# Altium schematic sheet Sheet1.SchDoc(Sheet1)
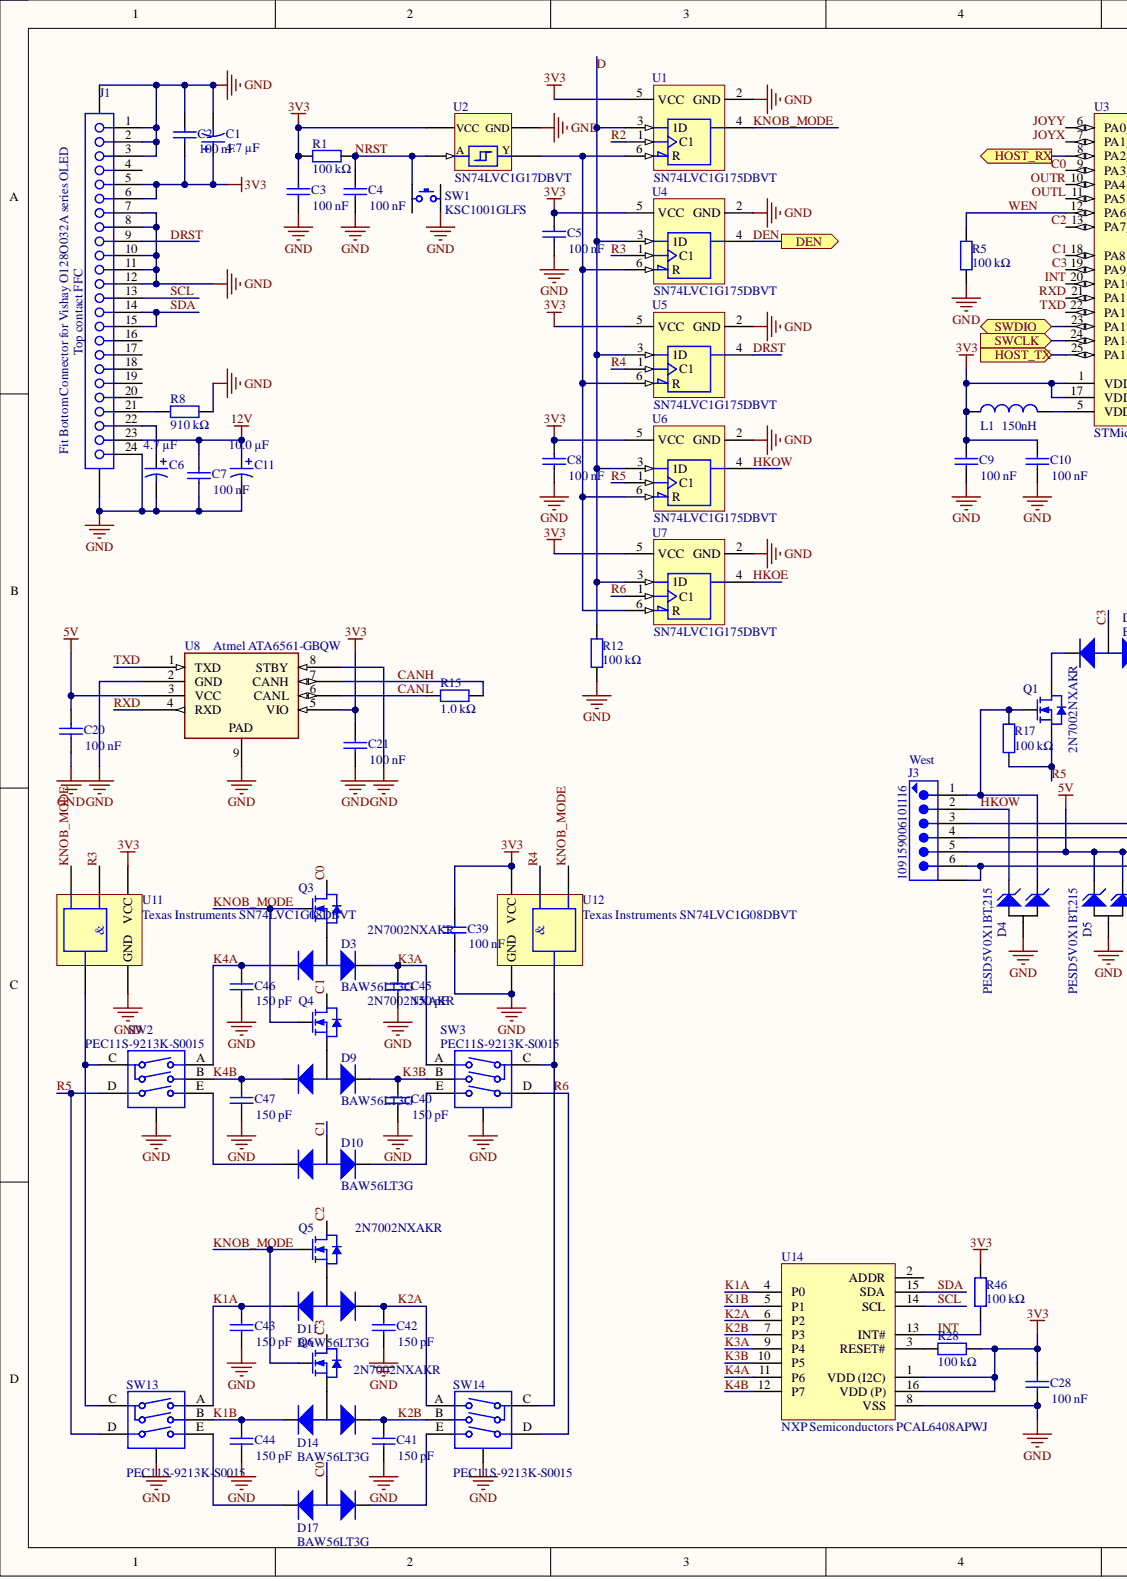
[diagram p0: Sheet1.SchDoc, left]
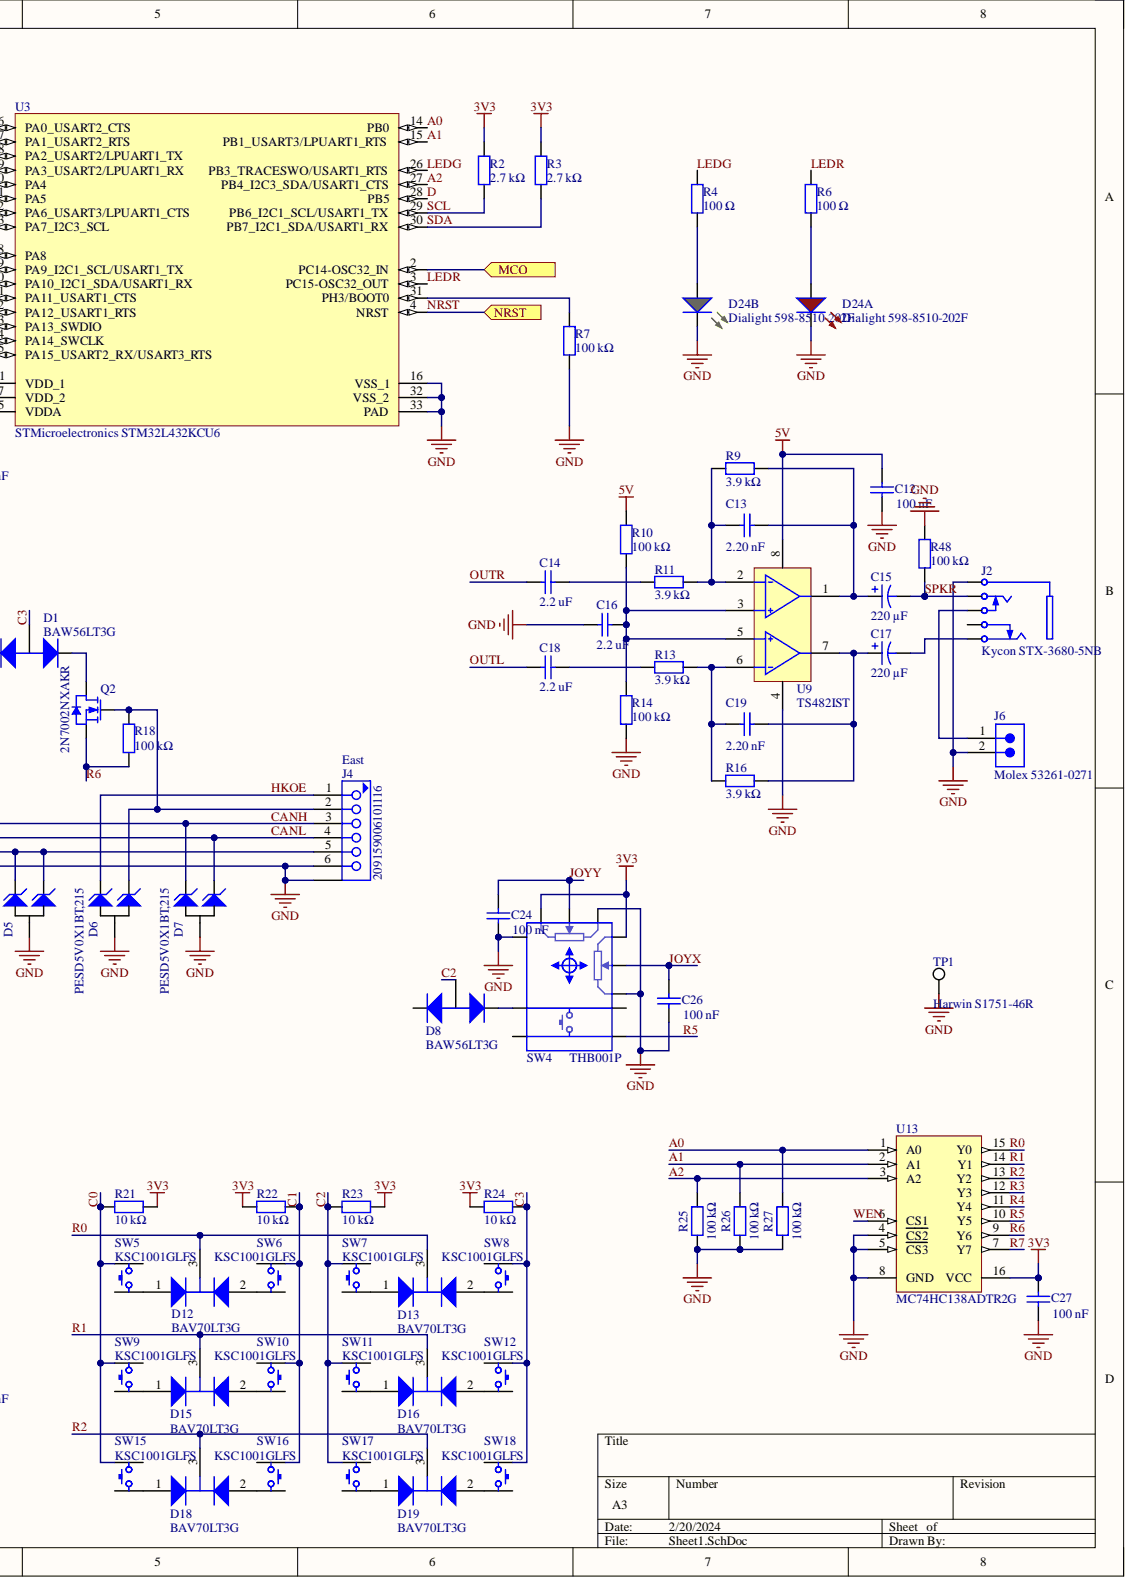
[diagram p1: Sheet1.SchDoc, right]

## Parts
C1  Capacitance: 4.7 µF  [PCB-4j0sn5jniln07mi7kvmx-1]  (hidden comment: AVX TAJB475K025RNJ)
C2  Yageo CC0603ZRY5V8BB104  (value not drawn)
C3  Yageo CC0603ZRY5V8BB104  (value not drawn)
C4  Capacitance: 100 nF  (hidden comment: Yageo CC0603ZRY5V8BB104)
C5  Capacitance: 100 nF  (hidden comment: Yageo CC0603ZRY5V8BB104)
C6  Capacitance: 4.7 µF  [PCB-4j0sn5jniln07mi7kvmx-1]  (hidden comment: AVX TAJB475K025RNJ)
C7  Yageo CC0603ZRY5V8BB104  (value not drawn)
C8  Capacitance: 100 nF  (hidden comment: Yageo CC0603ZRY5V8BB104)
C9  Yageo CC0603ZRY5V8BB104  (value not drawn)
C10  Capacitance: 100 nF  (hidden comment: Yageo CC0603ZRY5V8BB104)
C11  Capacitance: 10.0 µF  [PCB-4j0sn5jniln07mi7kvmx-1]  (hidden comment: AVX TAJB106K025RNJ)
C12  Capacitance: 100 nF  (hidden comment: Yageo CC0603ZRY5V8BB104)
C13  Capacitance: 2.20 nF  (hidden comment: Yageo CC0603KRX7R7BB222)
C14  Capacitance: 2.2 uF  (hidden comment: Yageo CC0603KRX5R8BB225)
C15  Capacitance: 220 µF  (hidden comment: Würth Elektronik 865080345012)
C16  Capacitance: 2.2 uF  (hidden comment: Yageo CC0603KRX5R8BB225)
C17  Capacitance: 220 µF  (hidden comment: Würth Elektronik 865080345012)
C18  Capacitance: 2.2 uF  (hidden comment: Yageo CC0603KRX5R8BB225)
C19  Capacitance: 2.20 nF  (hidden comment: Yageo CC0603KRX7R7BB222)
C20  Capacitance: 100 nF  (hidden comment: Yageo CC0603ZRY5V8BB104)
C21  Capacitance: 100 nF  (hidden comment: Yageo CC0603ZRY5V8BB104)
C24  Yageo CC0603ZRY5V8BB104  (value not drawn)
C26  Capacitance: 100 nF  (hidden comment: Yageo CC0603ZRY5V8BB104)
C27  Capacitance: 100 nF  (hidden comment: Yageo CC0603ZRY5V8BB104)
C28  Capacitance: 100 nF  (hidden comment: Yageo CC0603ZRY5V8BB104)
C39  Yageo CC0603ZRY5V8BB104  (value not drawn)
C40  Yageo CC0603JPNPO9BN151  (value not drawn)
C41  Yageo CC0603JPNPO9BN151  (value not drawn)
C42  Capacitance: 150 pF  (hidden comment: Yageo CC0603JPNPO9BN151)
C43  Yageo CC0603JPNPO9BN151  (value not drawn)
C44  Capacitance: 150 pF  (hidden comment: Yageo CC0603JPNPO9BN151)
C45  Capacitance: 150 pF  (hidden comment: Yageo CC0603JPNPO9BN151)
C46  Yageo CC0603JPNPO9BN151  (value not drawn)
C47  Capacitance: 150 pF  (hidden comment: Yageo CC0603JPNPO9BN151)
D1  BAW56LT3G
D3  BAW56LT3G
D4  Manufacturer Part Number: PESD5V0X1BT,215  (hidden comment: Nexperia PESD5V0X1BT,215)
D5  Manufacturer Part Number: PESD5V0X1BT,215  (hidden comment: Nexperia PESD5V0X1BT,215)
D6  Manufacturer Part Number: PESD5V0X1BT,215  (hidden comment: Nexperia PESD5V0X1BT,215)
D7  Manufacturer Part Number: PESD5V0X1BT,215  (hidden comment: Nexperia PESD5V0X1BT,215)
D8  BAW56LT3G
D9  BAW56LT3G
D10  BAW56LT3G
D11  BAW56LT3G
D12  BAV70LT3G
D13  BAV70LT3G
D14  BAW56LT3G
D15  BAV70LT3G
D16  BAV70LT3G
D17  BAW56LT3G
D18  BAV70LT3G
D19  BAV70LT3G
D24  Dialight 598-8510-202F  Manufacturer Part Number: 598-8510-202F  (parts A,B)
J1  52435-2433  (value not drawn)
J2  Kycon STX-3680-5NB  Manufacturer Part Number: STX-3680-5NB  [PCB-zl7nupvjblerxkmcmnl5-1]
J3  Manufacturer Part Number: 109159006101116  (hidden comment: AVX 109159006101116)
J4  209159006101116
J6  Molex 53261-0271  Manufacturer Part Number: 53261-0271
L1  value 150nH  (hidden comment: Eaton MCQ1V1608-R150-R)
Q1  Manufacturer Part Number: 2N7002NXAKR  (hidden comment: Nexperia 2N7002NXAKR)
Q2  Manufacturer Part Number: 2N7002NXAKR  (hidden comment: Nexperia 2N7002NXAKR)
Q3  Nexperia 2N7002NXAKR  (value not drawn)
Q4  Nexperia 2N7002NXAKR  (value not drawn)
Q5  Manufacturer Part Number: 2N7002NXAKR  (hidden comment: Nexperia 2N7002NXAKR)
Q6  Manufacturer Part Number: 2N7002NXAKR  (hidden comment: Nexperia 2N7002NXAKR)
R1  Resistance: 100 kΩ  (hidden comment: Yageo RC0603FR-07100KL)
R2  Resistance: 2.7 kΩ  (hidden comment: Yageo RC0603FR-102K7L)
R3  Resistance: 2.7 kΩ  (hidden comment: Yageo RC0603FR-102K7L)
R4  Resistance: 100 Ω  (hidden comment: Yageo RC0603FR-07100RL)
R5  Resistance: 100 kΩ  (hidden comment: Yageo RC0603FR-07100KL)
R6  Resistance: 100 Ω  (hidden comment: Yageo RC0603FR-07100RL)
R7  Resistance: 100 kΩ  (hidden comment: Yageo RC0603FR-07100KL)
R8  Resistance: 910 kΩ  (hidden comment: Yageo RC0603FR-07910KL)
R9  Resistance: 3.9 kΩ  (hidden comment: Yageo RC0603FR-073K9L)
R10  Resistance: 100 kΩ  (hidden comment: Yageo RC0603FR-07100KL)
R11  Resistance: 3.9 kΩ  (hidden comment: Yageo RC0603FR-073K9L)
R12  Resistance: 100 kΩ  (hidden comment: Yageo RC0603FR-07100KL)
R13  Resistance: 3.9 kΩ  (hidden comment: Yageo RC0603FR-073K9L)
R14  Resistance: 100 kΩ  (hidden comment: Yageo RC0603FR-07100KL)
R15  Resistance: 1.0 kΩ  (hidden comment: Yageo RC0603FR-071KL)
R16  Resistance: 3.9 kΩ  (hidden comment: Yageo RC0603FR-073K9L)
R17  Yageo RC0603FR-07100KL  (value not drawn)
R18  Resistance: 100 kΩ  (hidden comment: Yageo RC0603FR-07100KL)
R21  Resistance: 10 kΩ  (hidden comment: Yageo RC0603FR-0710KL)
R22  Resistance: 10 kΩ  (hidden comment: Yageo RC0603FR-0710KL)
R23  Resistance: 10 kΩ  (hidden comment: Yageo RC0603FR-0710KL)
R24  Resistance: 10 kΩ  (hidden comment: Yageo RC0603FR-0710KL)
R25  Resistance: 100 kΩ  (hidden comment: Yageo RC0603FR-07100KL)
R26  Resistance: 100 kΩ  (hidden comment: Yageo RC0603FR-07100KL)
R27  Resistance: 100 kΩ  (hidden comment: Yageo RC0603FR-07100KL)
R28  Resistance: 100 kΩ  (hidden comment: Yageo RC0603FR-07100KL)
R46  Resistance: 100 kΩ  (hidden comment: Yageo RC0603FR-07100KL)
R48  Resistance: 100 kΩ  (hidden comment: Yageo RC0603FR-07100KL)
SW1  Manufacturer Part Number: KSC1001GLFS  (hidden comment: C&K Components KSC1001GLFS)
SW2  Manufacturer Part Number: PEC11S-9213K-S0015  (hidden comment: Bourns PEC11S-9213K-S0015)
SW3  Manufacturer Part Number: PEC11S-9213K-S0015  (hidden comment: Bourns PEC11S-9213K-S0015)
SW4  Manufacturer Part Number: THB001P  (hidden comment: C&K Components THB001P)
SW5  Manufacturer Part Number: KSC1001GLFS  (hidden comment: C&K Components KSC1001GLFS)
SW6  Manufacturer Part Number: KSC1001GLFS  (hidden comment: C&K Components KSC1001GLFS)
SW7  Manufacturer Part Number: KSC1001GLFS  (hidden comment: C&K Components KSC1001GLFS)
SW8  Manufacturer Part Number: KSC1001GLFS  (hidden comment: C&K Components KSC1001GLFS)
SW9  Manufacturer Part Number: KSC1001GLFS  (hidden comment: C&K Components KSC1001GLFS)
SW10  Manufacturer Part Number: KSC1001GLFS  (hidden comment: C&K Components KSC1001GLFS)
SW11  Manufacturer Part Number: KSC1001GLFS  (hidden comment: C&K Components KSC1001GLFS)
SW12  Manufacturer Part Number: KSC1001GLFS  (hidden comment: C&K Components KSC1001GLFS)
SW13  Manufacturer Part Number: PEC11S-9213K-S0015  (hidden comment: Bourns PEC11S-9213K-S0015)
SW14  Manufacturer Part Number: PEC11S-9213K-S0015  (hidden comment: Bourns PEC11S-9213K-S0015)
SW15  Manufacturer Part Number: KSC1001GLFS  (hidden comment: C&K Components KSC1001GLFS)
SW16  Manufacturer Part Number: KSC1001GLFS  (hidden comment: C&K Components KSC1001GLFS)
SW17  Manufacturer Part Number: KSC1001GLFS  (hidden comment: C&K Components KSC1001GLFS)
SW18  Manufacturer Part Number: KSC1001GLFS  (hidden comment: C&K Components KSC1001GLFS)
TP1  Harwin S1751-46R  Manufacturer Part Number: S1751-46R
U1  Manufacturer Part Number: SN74LVC1G175DBVT  (hidden comment: Texas Instruments SN74LVC1G175DBV)
U2  SN74LVC1G17DBVT
U3  STMicroelectronics STM32L432KCU6
U4  Manufacturer Part Number: SN74LVC1G175DBVT  (hidden comment: Texas Instruments SN74LVC1G175DBV)
U5  Manufacturer Part Number: SN74LVC1G175DBVT  (hidden comment: Texas Instruments SN74LVC1G175DBV)
U6  Manufacturer Part Number: SN74LVC1G175DBVT  (hidden comment: Texas Instruments SN74LVC1G175DBV)
U7  Manufacturer Part Number: SN74LVC1G175DBVT  (hidden comment: Texas Instruments SN74LVC1G175DBV)
U8  Atmel ATA6561-GBQW  Manufacturer Part Number: ATA6561-GBQW
U9  Manufacturer Part Number: TS482IST  (hidden comment: STMicroelectronics TS482IST)
U11  Texas Instruments SN74LVC1G08DBVT
U12  Texas Instruments SN74LVC1G08DBVT
U13  MC74HC138ADTR2G
U14  NXP Semiconductors PCAL6408APWJ  Manufacturer Part Number: PCAL6408APWJ

## Ports
DEN, HOST_RX, HOST_TX, MCO, NRST, SWCLK, SWDIO

## Nets
3V3: C1.1 C2.2 C3.1 C5.1 C8.1 C9.1 C10.1 C21.1 C27.1 C28.1 C39.1 J1.5 J1.6 L1.1 R1.1 R2.2 R3.2 R21.1 R22.2 R23.1 R24.2 R28.1 R46.1 SW4.X3(nF) SW4.Y3 U1.5(VCC) U2.5(VCC) U3.1(VDD_1) U3.17(VDD_2) U4.5(VCC) U5.5(VCC) U6.5(VCC) U7.5(VCC) U8.5(VIO) U11.5(VCC) U12.5(VCC) U13.16(VCC) U14.1(VDD_(I2C)) U14.16(VDD_(P))
5V: C12.1 C20.1 D5.1 D5.2 J3.5 J4.5 R10.2 U8.3(VCC) U9.8
12V: C7.1 C11.1 J1.23
GND: C1.2 C2.1 C3.2 C4.2 C5.2 C6.2 C7.2 C8.2 C9.2 C10.2 C11.2 C12.2 C16.2 C20.2 C21.2 C24.2 C26.2 C27.2 C28.2 C39.2 C40.2 C41.2 C42.2 C43.2 C44.2 C45.2 C46.2 C47.2 D4.3 D5.3 D6.3 D7.3 D24.2 D24.4 J1.1 J1.2 J1.3 J1.7 J1.8 J1.10 J1.11 J1.12 J1.24 J2.5 J3.0 J3.6 J4.0 J4.6 J6.2 R5.1 R7.1 R8.2 R12.1 R14.1 R25.1 R26.1 R27.1 R48.2 SW1.3 SW2.0 SW3.0 SW4.0 SW4.X1 SW4.Y1 SW13.0 SW14.0 TP1.1 U1.2(GND) U2.3(GND) U3.16(VSS_1) U3.32(VSS_2) U3.33(PAD) U4.2(GND) U5.2(GND) U6.2(GND) U7.2(GND) U8.2(GND) U8.8(STBY) U8.9 U9.4 U11.3(GND) U12.3(GND) U13.4(CS2) U13.5(CS3) U13.8(GND) U14.8(VSS)
A0: R27.2 U3.14(PB0) U13.1(A0)
A1: R26.2 U3.15(PB1_USART3/LPUART1_RTS) U13.2(A1)
A2: R25.2 U3.27(PB4_I2C3_SDA/USART1_CTS) U13.3(A2)
C0: D17.3 Q3.3 R21.2 SW5.4 SW9.4 SW15.4 U3.9(PA3_USART2/LPUART1_RX)
C1: D10.3 Q4.3 R22.1 SW6.2 SW10.2 SW16.2 U3.18(PA8)
C2: D8.3 Q5.3 R23.2 SW7.4 SW11.4 SW17.4 U3.13(PA7_I2C3_SCL)
C3: D1.3 Q6.3 R24.1 SW8.2 SW12.2 SW18.2 U3.19(PA9_I2C1_SCL/USART1_TX)
CANH: D7.1 J3.3 J4.3 R15.1 U8.7(CANH)
CANL: D7.2 J3.4 J4.4 R15.2 U8.6(CANL)
D: R12.2 U1.3(1D) U3.28(PB5) U4.3(1D) U5.3(1D) U6.3(1D) U7.3(1D)
DEN: U4.4 ; port DEN
DRST: J1.9 U5.4
HKOE: D6.1 J4.1 U7.4
HKOW: D4.1 J3.2 U6.4
HOST_RX: U3.8(PA2_USART2/LPUART1_TX) ; port HOST_RX
HOST_TX: U3.25(PA15_USART2_RX/USART3_RTS) ; port HOST_TX
INT: R46.2 U3.20(PA10_I2C1_SDA/USART1_RX) U14.13(INT#)
JOYX: C26.1 SW4.Y2 U3.7(PA1_USART2_RTS)
JOYY: C24.1 SW4.X2 U3.6(PA0_USART2_CTS)
K1A: C43.1 D11.1 SW13.A U14.4(P0)
K1B: C44.1 D14.1 SW13.B U14.5(P1)
K2A: C42.1 D11.2 SW14.A U14.6(P2)
K2B: C41.1 D14.2 SW14.B U14.7(P3)
K3A: C45.1 D3.1 SW3.A U14.9(P4)
K3B: C40.1 D9.1 SW3.B U14.10(P5)
K4A: C46.1 D3.2 SW2.A U14.11(P6)
K4B: C47.1 D9.2 SW2.B U14.12(P7)
KNOB_MODE: Q3.1 Q4.1 Q5.1 Q6.1 U1.4 U11.2 U12.2
LEDG: R4.2 U3.26(PB3_TRACESWO/USART1_RTS)
LEDR: R6.2 U3.3(PC15-OSC32_OUT)
MCO: U3.2(PC14-OSC32_IN) ; port MCO
NRST: C4.1 R1.2 SW1.2 U2.2(A) U3.4(NRST) ; port NRST
OUTL: C18.2 U3.11(PA5)
OUTR: C14.2 U3.10(PA4)
R0: D12.3 D13.3 U13.15(Y0)
R1: D15.3 D16.3 U13.14(Y1)
R2: D18.3 D19.3 U1.1 U13.13(Y2)
R3: U4.1 U11.1 U13.12(Y3)
R4: U5.1 U12.1 U13.11(Y4)
R5: Q1.2 R17.1 SW2.D SW4.D SW13.D U6.1 U13.10(Y5)
R6: Q2.2 R18.1 SW3.D SW14.D U7.1 U13.9(Y6)
R7: U13.7(Y7)
RXD: U3.21(PA11_USART1_CTS) U8.4(RXD)
SCL: J1.13 R2.1 U3.29(PB6_I2C1_SCL/USART1_TX) U14.14(SCL)
SDA: J1.14 J1.15 R3.1 U3.30(PB7_I2C1_SDA/USART1_RX) U14.15(SDA)
SPKR: C15.2 J2.3 R48.1
SWCLK: U3.24(PA14_SWCLK) ; port SWCLK
SWDIO: U3.23(PA13_SWDIO) ; port SWDIO
TXD: U3.22(PA12_USART1_RTS) U8.1(TXD)
WEN: R5.2 U3.12(PA6_USART3/LPUART1_CTS) U13.6(CS1)
NetC6_1: C6.1 J1.22
NetC13_1: C13.1 R9.2 R11.1 U9.2
NetC13_2: C13.2 C15.1 R9.1 U9.1
NetC14_1: C14.1 R11.2
NetC16_1: C16.1 R10.1 R14.2 U9.3 U9.5
NetC17_1: C17.1 C19.2 R16.1 U9.7
NetC17_2: C17.2 J2.1
NetC18_1: C18.1 R13.2
NetC19_1: C19.1 R13.1 R16.2 U9.6
NetD1_1: D1.1 Q2.3
NetD1_2: D1.2 Q1.3
NetD3_3: D3.3 Q3.2
NetD4_2: D4.2 J3.1 Q1.1 R17.2
NetD6_2: D6.2 J4.2 Q2.1 R18.2
NetD8_1: D8.1 SW4.A
NetD9_3: D9.3 Q4.2
NetD10_1: D10.1 SW3.E
NetD10_2: D10.2 SW2.E
NetD11_3: D11.3 Q5.2
NetD12_1: D12.1 SW5.1
NetD12_2: D12.2 SW6.3
NetD13_1: D13.1 SW7.1
NetD13_2: D13.2 SW8.3
NetD14_3: D14.3 Q6.2
NetD15_1: D15.1 SW9.1
NetD15_2: D15.2 SW10.3
NetD16_1: D16.1 SW11.1
NetD16_2: D16.2 SW12.3
NetD17_1: D17.1 SW13.E
NetD17_2: D17.2 SW14.E
NetD18_1: D18.1 SW15.1
NetD18_2: D18.2 SW16.3
NetD19_1: D19.1 SW17.1
NetD19_2: D19.2 SW18.3
NetD24_1: D24.1 R4.1
NetD24_3: D24.3 R6.1
NetJ1_21: J1.21 R8.1
NetJ2_4: J2.4 J6.1
NetL1_2: L1.2 U3.5(VDDA)
NetR7_2: R7.2 U3.31(PH3/BOOT0)
NetR28_2: R28.2 U14.3(RESET#)
NetSW2_C: SW2.C SW13.C U11.4
NetSW3_C: SW3.C SW14.C U12.4
NetU1_6: U1.6(R) U2.4(Y) U4.6(R) U5.6(R) U6.6(R) U7.6(R)

Not connected: D8 2; J1 4 16 17 18 19 20 A B; J2 2; SW1 1 4; SW4 B C; SW5 2 3; SW6 1 4; SW7 2 3; SW8 1 4; SW9 2 3; SW10 1 4; SW11 2 3; SW12 1 4; SW15 2 3; SW16 1 4; SW17 2 3; SW18 1 4; U14 2(ADDR)

## Library data of the parts
C1 C6 = CMP-3881f1019f39e746-1: Cap Tant Solid 4.7uF 25V B CASE 10% (3.5 X 2.8 X 1.9mm) SMD 3528-21 1.8 Ohm 125Â°C T/R; Dielectric Material: Tantalum; Equivalent Series Resistance (ESR): 1.80 Ω; Lead-Free Status: Lead Free; Voltage Rating (DC): 25.0 V; Capacitance Tolerance: ±10%; Case/Package: 1210; Component Kind: Standard; Library Name: My Personal Space; Manufacturer Part Number: TAJB475K025RNJ; Mounting Style: Surface Mount; Number of Pins: 2; Octopart URL: http://octopart.com/tajb226k020rnj-avx-9077470; OctopartID: 3881f1019f39e746; Packaging: Cut Tape (CT), Tape & Reel (TR); REACH SVHC Compliance: No SVHC; RoHS: Compliant
C2 C3 C7 C9 C24 C39 = CMP-f2f83b8d074c2d3f-7: 0603 100 nF 25 V +80%/-20% Tolerance Y5V SMT Multilayer Ceramic Capacitor; Capacitance: 100 nF; Lead-Free Status: Lead Free; Voltage Rating (DC): 25 V; Capacitance Tolerance: +80%/-20%; Case/Package: 0603, 1608; Component Kind: Standard; Dielectric Characteristic: Y5V; Library Name: My Personal Space; Manufacturer Part Number: CC0603ZRY5V8BB104; Mounting Style: Surface Mount; Number of Pins: 2; Octopart Url: http://octopart.com/cc0603krx5r7bb105-yageo-8376530; OctopartID: f2f83b8d074c2d3f; Packaging: Cut Tape (CT); RoHS: Compliant; Seller Landing  Url: https://octopart-clicks.com/click/track?country=US&ak=8157f166&sig=0aaebbd&sid=1106&ppid=8376530&vpid=198824008&ct=offers
C4 C5 C8 C10 C12 C20 C21 C26-C28 = CMP-f2f83b8d074c2d3f-7: 0603 100 nF 25 V +80%/-20% Tolerance Y5V SMT Multilayer Ceramic Capacitor; Lead-Free Status: Lead Free; Voltage Rating (DC): 25 V; Capacitance Tolerance: +80%/-20%; Case/Package: 0603, 1608; Component Kind: Standard; Dielectric Characteristic: Y5V; Library Name: My Personal Space; Manufacturer Part Number: CC0603ZRY5V8BB104; Mounting Style: Surface Mount; Number of Pins: 2; Octopart Url: http://octopart.com/cc0603krx5r7bb105-yageo-8376530; OctopartID: f2f83b8d074c2d3f; Packaging: Cut Tape (CT); RoHS: Compliant; Seller Landing  Url: https://octopart-clicks.com/click/track?country=US&ak=8157f166&sig=0aaebbd&sid=1106&ppid=8376530&vpid=198824008&ct=offers
C11 = CMP-3881f1019f39e746-1: Cap Tant Solid 10uF 25V B CASE 10% (3.5 X 2.8 X 1.9mm) SMD 3528-21 1.8 Ohm 125Â°C T/R; Dielectric Material: Tantalum; Equivalent Series Resistance (ESR): 1.80 Ω; Lead-Free Status: Lead Free; Voltage Rating (DC): 25.0 V; Capacitance Tolerance: ±10%; Case/Package: 1210; Component Kind: Standard; Library Name: My Personal Space; Manufacturer Part Number: TAJB106K025RNJ; Mounting Style: Surface Mount; Number of Pins: 2; Octopart URL: http://octopart.com/tajb226k020rnj-avx-9077470; OctopartID: 3881f1019f39e746; Packaging: Cut Tape (CT), Tape & Reel (TR); REACH SVHC Compliance: No SVHC; RoHS: Compliant
C13 C19 = CMP-fe6f37e8117521a8-1: 0603 2.2 nF 16 V ±10 % Tolerance X7R SMT Multilayer Ceramic Capacitor; Capacitance Tolerance: ±10%; Lead-Free Status: Lead Free; Voltage Rating (DC): 16.0 V; Case/Package: 0603; Component Kind: Standard; Dielectric Characteristic: X7R; Halogen Free Status: Halogen Free; Library Name: FavoritesLibrary; Manufacturer Part Number: CC0603KRX7R7BB222; Mounting Style: Surface Mount; Octopart URL: https://octopart.com/cc0603krx7r7bb222-yageo-9292412; OctopartID: fe6f37e8117521a8; Packaging: Tape & Reel (TR); RoHS: Compliant
C14 C16 C18 = CMP-f2f83b8d074c2d3f-7: 0603 2.2 uF 25 V 10% Tolerance X5R SMT Multilayer Ceramic Capacitor; Lead-Free Status: Lead Free; Voltage Rating (DC): 25 V; Capacitance Tolerance: 10%; Case/Package: 0603, 1608; Component Kind: Standard; Dielectric Characteristic: X5R; Library Name: My Personal Space; Manufacturer Part Number: CC0603KRX5R8BB225; Mounting Style: Surface Mount; Number of Pins: 2; Octopart Url: http://octopart.com/cc0603krx5r7bb105-yageo-8376530; OctopartID: f2f83b8d074c2d3f; Packaging: Cut Tape (CT); RoHS: Compliant; Seller Landing  Url: https://octopart-clicks.com/click/track?country=US&ak=8157f166&sig=0aaebbd&sid=1106&ppid=8376530&vpid=198824008&ct=offers
C15 C17 = CMP-f9b2338e77042675-1: Smd Aluminium Electrolytic Capacitor, Wcap-Asli Series, 220 F, 16 V, Radial Can - Smd, 6.3 Mm Rohs Compliant: Yes; Voltage Rating (DC): 16.0 V; Component Kind: Standard; Library Name: CommunityVault; Manufacturer Part Number: 865080345012; Octopart URL: https://octopart.com/865080345012-w%C3%BCrth+elektronik-53914576; OctopartID: f9b2338e77042675; Operating Temperature: 105 °C (max); Packaging: Cut Tape (CT); REACH SVHC Compliance: No SVHC; RoHS: Compliant; Size-Diameter: 6.30 mm; Size-Height: 7.70 mm
C40 C41 C43 C46 = CMP-f2f83b8d074c2d3f-7: 0603 150 pF 50 V 5% Tolerance C0G SMT Multilayer Ceramic Capacitor; Capacitance: 150 pF; Capacitance Tolerance: +80%/-20%; Dielectric Characteristic: Y5V; Lead-Free Status: Lead Free; Voltage Rating (DC): 25 V; Case/Package: 0603, 1608; Component Kind: Standard; Library Name: My Personal Space; Manufacturer Part Number: CC0603JPNPO9BN151; Mounting Style: Surface Mount; Number of Pins: 2; Octopart Url: http://octopart.com/cc0603krx5r7bb105-yageo-8376530; OctopartID: f2f83b8d074c2d3f; Packaging: Cut Tape (CT); RoHS: Compliant; Seller Landing  Url: https://octopart-clicks.com/click/track?country=US&ak=8157f166&sig=0aaebbd&sid=1106&ppid=8376530&vpid=198824008&ct=offers
C42 C44 C45 C47 = CMP-f2f83b8d074c2d3f-7: 0603 150 pF 50 V 5% Tolerance C0G SMT Multilayer Ceramic Capacitor; Capacitance Tolerance: +80%/-20%; Dielectric Characteristic: Y5V; Lead-Free Status: Lead Free; Voltage Rating (DC): 25 V; Case/Package: 0603, 1608; Component Kind: Standard; Library Name: My Personal Space; Manufacturer Part Number: CC0603JPNPO9BN151; Mounting Style: Surface Mount; Number of Pins: 2; Octopart Url: http://octopart.com/cc0603krx5r7bb105-yageo-8376530; OctopartID: f2f83b8d074c2d3f; Packaging: Cut Tape (CT); RoHS: Compliant; Seller Landing  Url: https://octopart-clicks.com/click/track?country=US&ak=8157f166&sig=0aaebbd&sid=1106&ppid=8376530&vpid=198824008&ct=offers
D1 D3 D8-D11 D14 D17 = CMP-812967-3: Dual Switching Diodes, Common Anode, 3-Pin SOT-23, Pb-Free, Tape and Reel; Current Rating: 0.2 A; Lead-Free Status: Lead Free; Voltage Rating (DC): 70.0 V; Case/Package: SOT-23; Component Kind: Standard; Halogen Free Status: Halogen Free; Lifecycle Status: Active; Manufacturer Part Number: BAW56LT3G; Mounting Style: Surface Mount; Number of Pins: 3; Octopart Url: https://octopart.com/baw56lt3g-on+semiconductor-831687; OctopartID: 17cddecb826cc80a; Packaging: Tape; RoHS: Compliant
  D1: Library Name: CommunityVault
  D3 D8-D11 D14 D17: Library Name: FavoritesLibrary
D4-D7 = CMP-e0b62d0dbb0a3f71-6: PESD5V0X1BT Series 9.5 V 2.6 pF Bi-Directional ESD Protection Diode - SOT-23-3; Lead-Free Status: Lead Free; Case/Package: SOT-23; Component Kind: Standard; Library Name: CommunityVault; Lifecycle Status: Active; Mounting Style: Surface Mount; Octopart URL: https://octopart.com/pesd5v0x1bt%2C215-nexperia-78741210; OctopartID: e0b62d0dbb0a3f71; Packaging: Tape & Reel (TR)
D12 D13 D15 D16 D18 D19 = CMP-812966-2: Dual Switching Diodes, Common Cathode, 3-Pin SOT-23, Pb-Free, Tape and Reel; Current Rating: 0.2 A; Lead-Free Status: Lead Free; Voltage Rating (DC): 70.0 V; Case/Package: SOT-23; Component Kind: Standard; Halogen Free Status: Halogen Free; Library Name: ProjectLibrary; Lifecycle Status: Active; Manufacturer Part Number: BAV70LT3G; Mounting Style: Surface Mount; Octopart Url: https://octopart.com/bav70lt3g-on+semiconductor-700793; OctopartID: e0022902741d3fc5; Packaging: Tape; RoHS: Compliant
D24 = CMP-6379aa2ba535bcbe-1: 1208 SMT Led, Red/green, Alingap , Water Clear Lens, 160 Deg Viewing Angle; Color: Red, Green; Forward Voltage: 2.00 V; Lens Color: Red; Luminous Intensity: 80.0 mcd; Viewing Angle: 160 °; Wavelength: 645 nm; Component Kind: Standard; Library Name: My Personal Space; Mounting Style: Surface Mount; Number of Pins: 4; Octopart Url: https://octopart.com/598-8510-202f-dialight-5825322; OctopartID: 6379aa2ba535bcbe; Operating Temperature: -40.0 °C to 100 °C; RoHS: Compliant
J1 = CMP-19c3d13042d7c604-2: Conn FFC/FPC Connector SKT 24 POS 0.5mm Solder RA SMD Embossed T/R; Component Kind: Standard; Library Name: CommunityVault; Manufacturer Part Number: 52435-2433
J2 = CMP-e1db29e3e4af3e1c-2: Conn Audio F 5 POS Solder ST Thru-Hole 5 Terminal 1 Port; Gender: Female; Color: Black; Component Kind: Standard; Library Name: My Personal Space; Mounting Style: Through Hole; Octopart URL: https://octopart.com/stx-3680-5nb-kycon-20062226; OctopartID: e1db29e3e4af3e1c; Packaging: Tray; RoHS: Compliant
J3 = CMP-32a1fb1b020ced66-2: 9159 Series Board to Board Straight Header/Plug 3 mm Solder Horizontal Plug; Gender: Male; Lead-Free Status: Lead Free; Number of Contacts: 6; Color: White; Component Kind: Standard; Contact Material: Copper Alloy; Contact Plating: Gold; Library Name: CommunityVault; Mounting Style: Horizontal, Surface Mount; Octopart URL: https://octopart.com/109159006101116-avx-11539915; OctopartID: 32a1fb1b020ced66; Packaging: Reel; RoHS: Compliant
J4 = CMP-82614bdbb2e05da6-2: Conn Board to Board SKT 6 POS 3mm Solder RA SMD T/R; Gender: Female; Lead-Free Status: Lead Free; Number of Contacts: 6; Color: White; Component Kind: Standard; Contact Material: Copper Alloy; Contact Plating: Gold; Library Name: CommunityVault; Manufacturer Part Number: 209159006101116; Mounting Style: Horizontal, Surface Mount; Number of Positions: 6; Octopart URL: https://octopart.com/209159006101116-avx-11539943; RoHS: Compliant
J6 = CMP-7c69b56aa6cea3ae-6: Picoblade Header, 1.25mm, 2 Way, Right Angle, SMD; Current Rating: 5.50 A; Gender: Male; Glow Wire Compliant: Non-Compliant; Insulation Resistance: 100 MΩ; Lead-Free Status: Lead Free; Number of Circuits: 2; Voltage Rating (AC): 125 V; Color: Beige; Component Kind: Standard; Contact Material: Phosphor Bronze; Contact Plating: Tin; Flammability Rating: UL 94 V-0; Halogen Free Status: Not Low Halogen; Housing Material: Nylon; Library Name: My Personal Space; Lifecycle Status: Active; Mounting Style: Surface Mount; Number of Contacts: 2; Number of Positions: 2; Number of Rows: 1; Octopart URL: http://octopart.com/53261-0271-molex-655297; OctopartID: 7c69b56aa6cea3ae; Orientation: Right Angle; Packaging: Reel, Cut Tape (CT); Pin Pitch: 1.25 mm; REACH SVHC Compliance: No SVHC; RoHS: Compliant; Size-Height: 3.40 mm; Size-Length: 7.65 mm; Size-Width: 4.20 mm; Voltage Rating (DC): 125 V
L1 = CMP-5b4b94ead221848c-1: Ind Chip Unshielded 0.15uH 10% 25MHz 20Q-Factor 50mA 0603; Component Kind: Standard; Library Name: My Personal Space; Manufacturer Part Number: MCQ1V1608-R150-R; Octopart Url: https://octopart.com/mcq1v1608-r150-r-eaton-111913996; OctopartID: 5b4b94ead221848c
Q1-Q6 = CMP-ee2f2100224d31e8-3: 2N7002NXAK - 60 V, single N-channel Trench MOSFET; Component Kind: Standard; Octopart URL: https://octopart.com/2n7002nxakr-nexperia-103147780; OctopartID: ee2f2100224d31e8
  Q1 Q5 Q6: Library Name: FavoritesLibrary
  Q2: Library Name: CommunityVault
  Q3 Q4: Library Name: FavoritesLibrary; Manufacturer Part Number: 2N7002NXAKR
R1 R5 R7 R8 R10 R12 R14 R18 R25-R28 R46 R48 = CMP-9abf51c576ad4578-19: Res General Purpose Thick Film 0603 100k Ohm 1% 1/10W ±100ppm/°C Molded Paper T/R; Case/Package (SI): 1608; Lead-Free Status: Lead Free; Power Rating: 100 mW; Temperature Coefficient: -100 ppm/°C to 100 ppm/°C; Voltage Rating (DC): 75.0 V, 50.0 V; Case/Package: 0603; Component Kind: Standard; Library Name: CommunityVault; Mounting Style: Surface Mount; Number of Pins: 2; Octopart URL: https://octopart.com/rc0603fr-07100kl-yageo-40946036; OctopartID: 9abf51c576ad4578; Operating Temperature: 155 °C (max); Packaging: Tape & Reel; REACH SVHC Compliance: No SVHC; Resistance Tolerance: ±1%; RoHS: Compliant; Size-Height: 450 µm; Size-Length: 1.60 mm; Size-Width: 800 µm
  R1 R5 R7 R10 R12 R14 R18 R25-R28 R46 R48: Manufacturer Part Number: RC0603FR-07100KL
  R8: Manufacturer Part Number: RC0603FR-07910KL
R2 R3 = CMP-9abf51c576ad4578-19: Res General Purpose Thick Film 0603 2.7k Ohm 1% 1/10W ±100ppm/°C Molded Paper T/R; Case/Package (SI): 1608; Lead-Free Status: Lead Free; Power Rating: 100 mW; Temperature Coefficient: -100 ppm/°C to 100 ppm/°C; Voltage Rating (DC): 75.0 V, 50.0 V; Case/Package: 0603; Component Kind: Standard; Library Name: CommunityVault; Manufacturer Part Number: RC0603FR-102K7L; Mounting Style: Surface Mount; Number of Pins: 2; Octopart URL: https://octopart.com/rc0603fr-07100kl-yageo-40946036; OctopartID: 9abf51c576ad4578; Operating Temperature: 155 °C (max); Packaging: Tape & Reel; REACH SVHC Compliance: No SVHC; Resistance Tolerance: ±1%; RoHS: Compliant; Size-Height: 450 µm; Size-Length: 1.60 mm; Size-Width: 800 µm
R4 R6 = CMP-9abf51c576ad4578-19: Res General Purpose Thick Film 0603 100 Ohm 1% 1/10W ±100ppm/°C Molded Paper T/R; Case/Package (SI): 1608; Lead-Free Status: Lead Free; Power Rating: 100 mW; Temperature Coefficient: -100 ppm/°C to 100 ppm/°C; Voltage Rating (DC): 75.0 V, 50.0 V; Case/Package: 0603; Component Kind: Standard; Library Name: CommunityVault; Manufacturer Part Number: RC0603FR-07100RL; Mounting Style: Surface Mount; Number of Pins: 2; Octopart URL: https://octopart.com/rc0603fr-07100kl-yageo-40946036; OctopartID: 9abf51c576ad4578; Operating Temperature: 155 °C (max); Packaging: Tape & Reel; REACH SVHC Compliance: No SVHC; Resistance Tolerance: ±1%; RoHS: Compliant; Size-Height: 450 µm; Size-Length: 1.60 mm; Size-Width: 800 µm
R9 R11 R13 R16 = CMP-9abf51c576ad4578-19: Res General Purpose Thick Film 0603 3.9k Ohm 1% 1/10W ±100ppm/°C Molded Paper T/R; Case/Package (SI): 1608; Lead-Free Status: Lead Free; Power Rating: 100 mW; Temperature Coefficient: -100 ppm/°C to 100 ppm/°C; Voltage Rating (DC): 75.0 V, 50.0 V; Case/Package: 0603; Component Kind: Standard; Library Name: CommunityVault; Manufacturer Part Number: RC0603FR-073K9L; Mounting Style: Surface Mount; Number of Pins: 2; Octopart URL: https://octopart.com/rc0603fr-07100kl-yageo-40946036; OctopartID: 9abf51c576ad4578; Operating Temperature: 155 °C (max); Packaging: Tape & Reel; REACH SVHC Compliance: No SVHC; Resistance Tolerance: ±1%; RoHS: Compliant; Size-Height: 450 µm; Size-Length: 1.60 mm; Size-Width: 800 µm
R15 = CMP-9abf51c576ad4578-19: Res General Purpose Thick Film 0603 1.0k Ohm 1% 1/10W ±100ppm/°C Molded Paper T/R; Case/Package (SI): 1608; Lead-Free Status: Lead Free; Power Rating: 100 mW; Temperature Coefficient: -100 ppm/°C to 100 ppm/°C; Voltage Rating (DC): 75.0 V, 50.0 V; Case/Package: 0603; Component Kind: Standard; Library Name: CommunityVault; Manufacturer Part Number: RC0603FR-071KL; Mounting Style: Surface Mount; Number of Pins: 2; Octopart URL: https://octopart.com/rc0603fr-07100kl-yageo-40946036; OctopartID: 9abf51c576ad4578; Operating Temperature: 155 °C (max); Packaging: Tape & Reel; REACH SVHC Compliance: No SVHC; Resistance Tolerance: ±1%; RoHS: Compliant; Size-Height: 450 µm; Size-Length: 1.60 mm; Size-Width: 800 µm
R17 = CMP-9abf51c576ad4578-19: Res General Purpose Thick Film 0603 100k Ohm 1% 1/10W ±100ppm/°C Molded Paper T/R; Case/Package (SI): 1608; Lead-Free Status: Lead Free; Power Rating: 100 mW; Resistance: 100 kΩ; Temperature Coefficient: -100 ppm/°C to 100 ppm/°C; Voltage Rating (DC): 75.0 V, 50.0 V; Case/Package: 0603; Component Kind: Standard; Library Name: CommunityVault; Manufacturer Part Number: RC0603FR-07100KL; Mounting Style: Surface Mount; Number of Pins: 2; Octopart URL: https://octopart.com/rc0603fr-07100kl-yageo-40946036; OctopartID: 9abf51c576ad4578; Operating Temperature: 155 °C (max); Packaging: Tape & Reel; REACH SVHC Compliance: No SVHC; Resistance Tolerance: ±1%; RoHS: Compliant; Size-Height: 450 µm; Size-Length: 1.60 mm; Size-Width: 800 µm
R21-R24 = CMP-9abf51c576ad4578-19: Res General Purpose Thick Film 0603 10k Ohm 1% 1/10W ±100ppm/°C Molded Paper T/R; Case/Package (SI): 1608; Lead-Free Status: Lead Free; Power Rating: 100 mW; Temperature Coefficient: -100 ppm/°C to 100 ppm/°C; Voltage Rating (DC): 75.0 V, 50.0 V; Case/Package: 0603; Component Kind: Standard; Library Name: CommunityVault; Manufacturer Part Number: RC0603FR-0710KL; Mounting Style: Surface Mount; Number of Pins: 2; Octopart URL: https://octopart.com/rc0603fr-07100kl-yageo-40946036; OctopartID: 9abf51c576ad4578; Operating Temperature: 155 °C (max); Packaging: Tape & Reel; REACH SVHC Compliance: No SVHC; Resistance Tolerance: ±1%; RoHS: Compliant; Size-Height: 450 µm; Size-Length: 1.60 mm; Size-Width: 800 µm
SW1 SW5-SW12 SW15-SW18 = CMP-5a3d9777ce7585fa-1: KSC10 Series Sealed Tactile Switch SPST-NO Round Button 0.05A 32VDC Gull Wing Surface Mount T/R; Component Kind: Standard; Library Name: FavoritesLibrary; Octopart URL: https://octopart.com/ksc1001glfs-c%26k+components-71348526; OctopartID: 5a3d9777ce7585fa; RoHS: Compliant
SW2 SW3 SW13 SW14 = CMP-cacf02e955d31508-3: 15 Pulseincremental Mechanical Rotary Encoder w/ 6mm Knurl Shaft(Notindexed); SMD; Component Kind: Standard; Library Name: CommunityVault; Octopart URL: https://octopart.com/pec11s-9213k-s0015-bourns-19708538; OctopartID: cacf02e955d31508
SW4 = CMP-93c75ca5b8734c00-2: Multi-Directional Thumbstick, 2 Axis, Center Momentary Switch, 12VDC, THB Series; Component Kind: Standard; Library Name: CommunityVault; Octopart URL: https://octopart.com/thb001p-c%26k+components-107482834; OctopartID: 93c75ca5b8734c00
TP1 = CMP-5a4e934f964b8589-6: Terminals and Hardware SMT TEST TERMINAL TIN; Component Kind: Standard; Contact Material: Copper Alloy; Contact Plating: Tin; Library Name: My Personal Space; Mounting Style: Surface Mount; OctopartID: 5a4e934f964b8589; REACH SVHC Compliance: No SVHC; RoHS: Compliant
U1 U4-U7 = CMP-143add5c53a5cbfd-2: Single D-Type Flip-Flop with Asynchronous Clear 6-SOT-23 -40 to 125; Output Current: 32.0 mA; Case/Package: SOT-23; Component Kind: Standard; Library Name: CommunityVault; Mounting Style: Surface Mount; Number of Pins: 6; Octopart URL: https://octopart.com/sn74lvc1g175dbvt-texas+instruments-7103225; Packaging: Cut Tape (CT)
U2 = CMP-72dabb83c606ad1d-2: Low-Power Single Schmitt-Trigger Buffer 5-SOT-23 -40 to 85; Lead-Free Status: Lead Free; Number of Bits: 1; Propagation Delay Max (tpd): 6.20 ns, 5.10 ns, 7.70 ns, 5.70 ns; Supply Voltage (DC): 3.60 V (max), 800 mV (min); Case/Package: SOT-23; Component Kind: Standard; Library Name: CommunityVault; Lifecycle Status: Active; Manufacturer Part Number: SN74LVC1G17DBVT; Number of Pins: 5; Octopart URL: https://octopart.com/sn74aup1g17dbvt-texas+instruments-5476794; OctopartID: 72dabb83c606ad1d; Operating Temperature: -40.0 °C to 85.0 °C; Packaging: Tape & Reel (TR); REACH SVHC Compliance: No SVHC; RoHS: Compliant
U3 = CMP-ea062730026635c1-7: MCU 32-Bit STM32L432xx ARM Cortex M4 RISC 256KB Flash 1.8V/2.5V/3.3V 32-Pin UFQFPN Tray; Component Kind: Standard; Library Name: My Personal Space
U8 = CMP-9a565056add04591-8: CAN 5Mbps Normal/Silent/Standby 5V Automotive 8-Pin VDFN EP T/R; Component Kind: Standard; Library Name: CommunityVault; Octopart URL: http://octopart.com/ata6561-gbqw-atmel-50991840; OctopartID: 9a565056add04591; RoHS: Compliant
U9 = CMP-1b6fd263047ec4a8-3: Audio Amp Headphone 2-CH Stereo 0.107W Class-AB 8-Pin MSOP T/R; Component Kind: Standard; Library Name: CommunityVault
U11 U12 = CMP-4113883a1b399ed4-1: Single 2-input, 1.65-V to 5.5-V AND gate 5-SOT-23 -40 to 125; Lead-Free Status: Lead Free; Number of Bits: 1; Output Current: 32.0 mA; Output Current Drive: -1.00 mA; Propagation Delay Max (tpd): 4.00 ns; Supply Voltage (DC): 1.65 V to 5.50 V; Voltage Nodes: 1.80 V, 5.00 V, 3.30 V, 2.50 V; Case/Package: SOT-23; Component Kind: Standard; Library Name: My Personal Space; Lifecycle Status: Active; Manufacturer Part Number: SN74LVC1G08DBVT; Mounting Style: Surface Mount; Number of Gates: 1; Number of Pins: 5; Octopart Url: https://octopart.com/sn74lvc1g08dbvt-texas+instruments-2326348; OctopartID: 4113883a1b399ed4; Operating Temperature: -40.0 °C to 85.0 °C; Packaging: Cut Tape (CT); REACH SVHC Compliance: No SVHC; RoHS: Compliant
U13 = CMP-f38f3a894bcb2c48-1: 74HC Series 2 to 6 V CMOS 1-of-8 Decoder/Demultiplexer - TSSOP-16; Lead-Free Status: Lead Free; Supply Voltage (DC): 2.00 V (min); Case/Package: TSSOP; Component Kind: Standard; Halogen Free Status: Halogen Free; Library Name: CommunityVault; Lifecycle Status: Active; Manufacturer Part Number: MC74HC138ADTR2G; Number of Pins: 16; Octopart URL: http://octopart.com/mc74hc138adtr2g-on+semiconductor-826658; OctopartID: f38f3a894bcb2c48; Packaging: Cut Tape (CT); RoHS: Compliant
U14 = CMP-5cbb94c8e436837c-1: I2C/SMBus Interface 100kHz/400kHz 5.5V 16-Pin TSSOP T/R; Lead-Free Status: Lead Free; Supply Voltage (DC): 5.50 V (max), 1.65 V (min); Component Kind: Standard; Library Name: My Personal Space; Lifecycle Status: Active; Mounting Style: Surface Mount; Number of Pins: 16; Octopart Url: https://octopart.com/pcal6408apwj-nxp+semiconductors-24747499; OctopartID: 5cbb94c8e436837c; Packaging: Cut Tape (CT); REACH SVHC Compliance: No SVHC; RoHS: Compliant
